# Altium schematic sheet DEVICE2.SchDoc(DEVICE2)
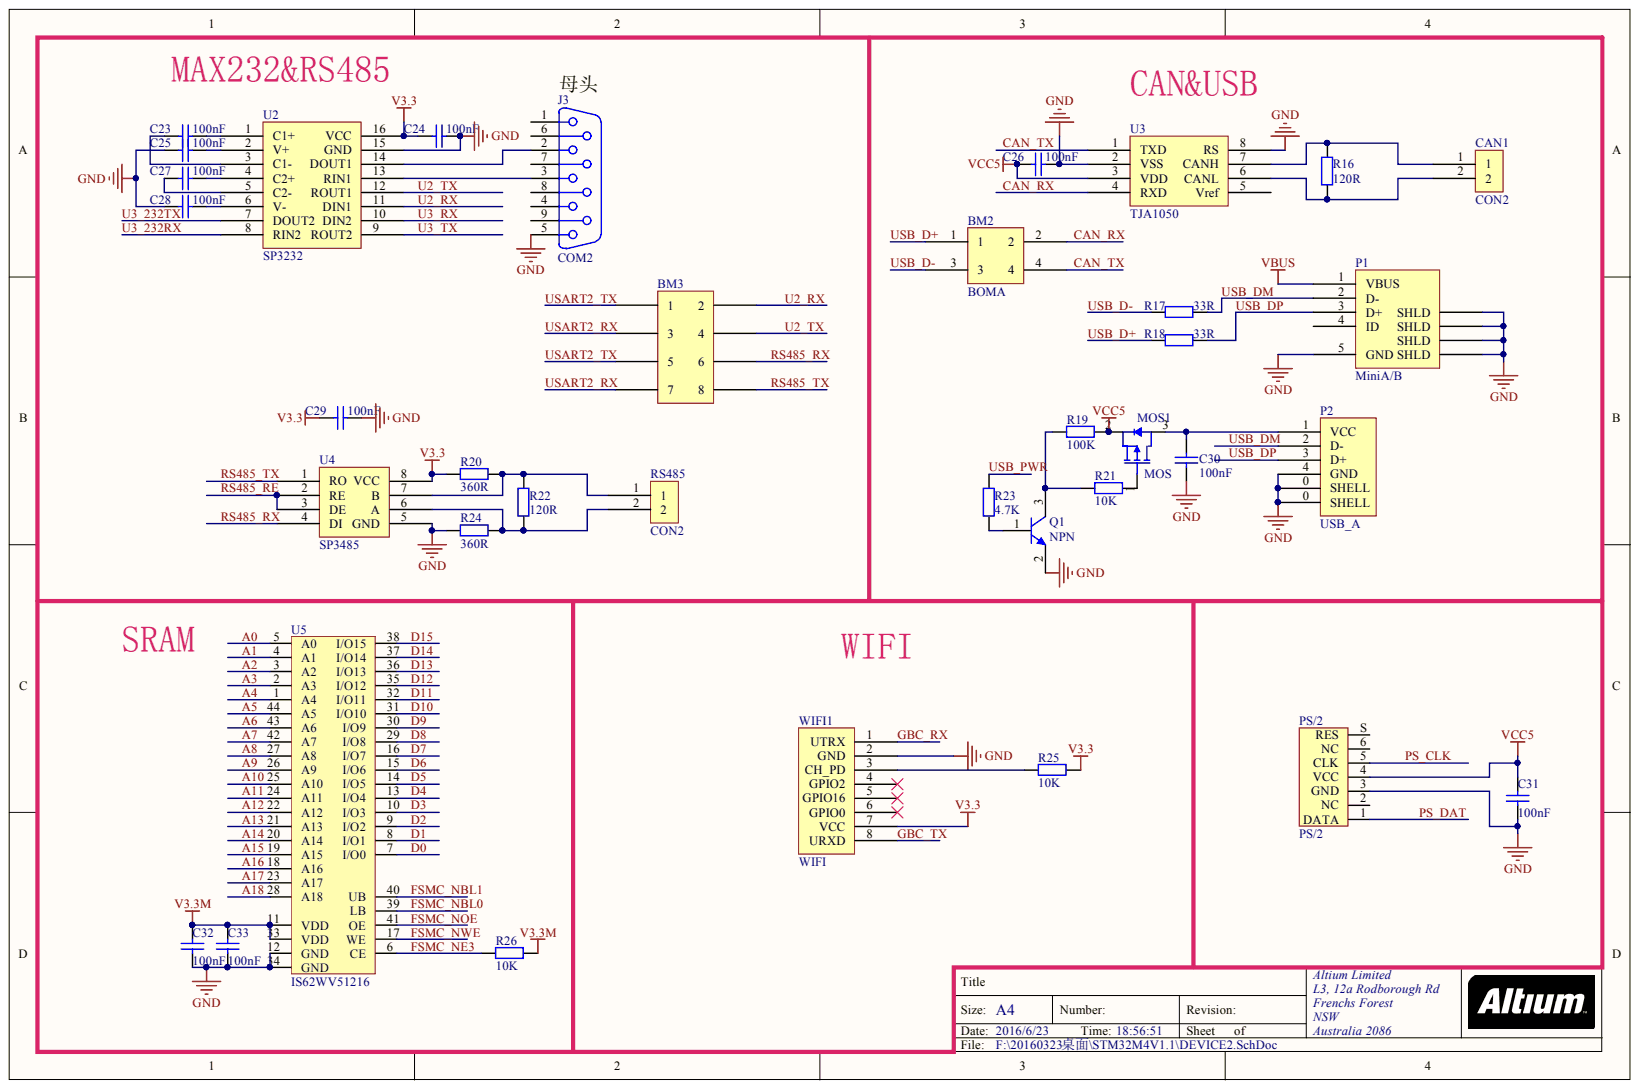
[diagram p0: DEVICE2.SchDoc]

## Parts
BM2  BOMA  [BM]
BM3  BOMA4  [BM4]  (value not drawn)
C23  value 100nF  [0603]  (hidden comment: Cap)
C24  value 100nF  [0603]  (hidden comment: Cap)
C25  value 100nF  [0603]  (hidden comment: Cap)
C26  value 100nF  [0603]  (hidden comment: Cap)
C27  value 100nF  [0603]  (hidden comment: Cap)
C28  value 100nF  [0603]  (hidden comment: Cap)
C29  value 100nF  [0603]  (hidden comment: Cap)
C30  value 100nF  [0603]  (hidden comment: Cap)
C31  value 100nF  [0603]  (hidden comment: Cap)
C32  value 100nF  [0603]  (hidden comment: Cap)
C33  value 100nF  [0603]  (hidden comment: Cap)
CAN1  CON2  [CON2]
J3  COM2  [DB9]
MOS1  MOS  [SOT-23]
P1  MiniA/B  [MINI_USB]
P2  USB_A  [USB_A]
PS/2  PS/2  [PS2-6PIN]
Q1  NPN
R16  value 120R  [0603]  (hidden comment: Res2)
R17  value 33R  [0603]  (hidden comment: Res2)
R18  value 33R  [0603]  (hidden comment: Res2)
R19  value 100K  [0603]  (hidden comment: Res2)
R20  value 360R  [0603]  (hidden comment: Res2)
R21  value 10K  [0603]  (hidden comment: Res2)
R22  value 120R  [0603]  (hidden comment: Res2)
R23  value 4.7K  [0603]  (hidden comment: Res2)
R24  value 360R  [0603]  (hidden comment: Res2)
R25  value 10K  [0603]  (hidden comment: Res2)
R26  value 10K  [0603]  (hidden comment: Res2)
RS485  CON2  [CON2]
U2  SP3232  [SOIC16]
U3  TJA1050  [SOIC8]
U4  SP3485  [SOIC8]
U5  IS62WV51216  [TSOP44]
WIFI1  WIFI  [WPZ_2x4]

## Nets
GND: C24.1 C25.2 C26.1 C28.2 C29.1 C30.2 C31.2 C32.2 C33.2 J3.5 P1.5(GND) P1.6(SHLD) P1.7(SHLD) P1.8(SHLD) P1.9(SHLD) P2.0(SHELL) P2.4(GND) PS/2.3(GND) Q1.2 R24.2 U2.15(GND) U3.2(VSS) U3.8(RS) U4.5(GND) U5.12(GND) U5.34(GND) WIFI1.2(GND)
V3.3: C24.2 C29.2 R20.2 R25.1 U2.16(VCC) U4.8(VCC) WIFI1.7(VCC)
V3.3M: C32.1 C33.1 R26.1 U5.11(VDD) U5.33(VDD)
VBUS: P1.1(VBUS)
VCC5: C26.2 C31.1 MOS1.2 PS/2.4(VCC) R19.1 U3.3(VDD)
A0: U5.5(A0)
A1: U5.4(A1)
A2: U5.3(A2)
A3: U5.2(A3)
A4: U5.1(A4)
A5: U5.44(A5)
A6: U5.43(A6)
A7: U5.42(A7)
A8: U5.27(A8)
A9: U5.26(A9)
A10: U5.25(A10)
A11: U5.24(A11)
A12: U5.22(A12)
A13: U5.21(A13)
A14: U5.20(A14)
A15: U5.19(A15)
A16: U5.18(A16)
A17: U5.23(A17)
A18: U5.28(A18)
CAN_RX: BM2.2 U3.4(RXD)
CAN_TX: BM2.4 U3.1(TXD)
D0: U5.7(I/O0)
D1: U5.8(I/O1)
D2: U5.9(I/O2)
D3: U5.10(I/O3)
D4: U5.13(I/O4)
D5: U5.14(I/O5)
D6: U5.15(I/O6)
D7: U5.16(I/O7)
D8: U5.29(I/O8)
D9: U5.30(I/O9)
D10: U5.31(I/O10)
D11: U5.32(I/O11)
D12: U5.35(I/O12)
D13: U5.36(I/O13)
D14: U5.37(I/O14)
D15: U5.38(I/O15)
FSMC_NBL0: U5.39(LB)
FSMC_NBL1: U5.40(UB)
FSMC_NE3: R26.2 U5.6(CE)
FSMC_NOE: U5.41(OE)
FSMC_NWE: U5.17(WE)
GBC_RX: WIFI1.1(UTRX)
GBC_TX: WIFI1.8(URXD)
PS_CLK: PS/2.5(CLK)
PS_DAT: PS/2.1(DATA)
RS485_RE: U4.2(RE) U4.3(DE)
RS485_RX: BM3.6 U4.4(DI)
RS485_TX: BM3.8 U4.1(RO)
U2_RX: BM3.2 U2.11(DIN1)
U2_TX: BM3.4 U2.12(ROUT1)
U3_232RX: U2.8(RIN2)
U3_232TX: U2.7(DOUT2)
U3_RX: U2.10(DIN2)
U3_TX: U2.9(ROUT2)
USART2_RX: BM3.3 BM3.7
USART2_TX: BM3.1 BM3.5
USB_D+: BM2.1 R18.2
USB_D-: BM2.3 R17.2
USB_DM: P1.2(D-) P2.2(D-) R17.1
USB_DP: P1.3(D+) P2.3(D+) R18.1
USB_PWR: R23.1
NetC23_1: C23.1 U2.1(C1+)
NetC23_2: C23.2 U2.3(C1-)
NetC25_1: C25.1 U2.2(V+)
NetC27_1: C27.1 U2.4(C2+)
NetC27_2: C27.2 U2.5(C2-)
NetC28_1: C28.1 U2.6(V-)
NetC30_1: C30.1 MOS1.3 P2.1(VCC)
NetCAN1_1: CAN1.1 R16.2 U3.7(CANH)
NetCAN1_2: CAN1.2 R16.1 U3.6(CANL)
NetJ3_2: J3.2 U2.14(DOUT1)
NetJ3_3: J3.3 U2.13(RIN1)
NetMOS1_1: MOS1.1 R21.1
NetQ1_1: Q1.1 R23.2
NetQ1_3: Q1.3 R19.2 R21.2
NetR20_1: R20.1 R22.2 RS485.1 U4.7(B)
NetR22_1: R22.1 R24.1 RS485.2 U4.6(A)
NetR25_2: R25.2 WIFI1.3(CH_PD)

Not connected: J3 1 4 6 7 8 9; P1 4(ID); PS/2 2(NC) 6(NC) S(RES); U3 5(Vref); WIFI1 4(GPIO2) 5(GPIO16) 6(GPIO0)

## Library data of the parts
C23-C33 = Cap: Capacitor; Component Kind: Standard; LatestRevisionDate: 17-Jul-2002; LatestRevisionNote: Re-released for DXP Platform.; Library Name: Miscellaneous Devices.IntLib; PackageDescription: Radial Cap, Thru-Hole; 2 Leads; 0.3 in Pin Spacing; PackageReference: RAD-0.3; Published: 8-Jun-2000; Publisher: Altium Limited
  C23-C30 C32 C33: Signal Integrity: Cap; Simulation: CAP
J3 = DB-9: Component Kind: Standard; Library Name: MyLibrary.IntLib
PS/2 = P/S2: Component Kind: Standard; Library Name: 战舰STM32开发板底板封装库.IntLib
R16-R26 = Res2: Resistor; Component Kind: Standard; LatestRevisionDate: 17-Jul-2002; LatestRevisionNote: Re-released for DXP Platform.; Library Name: Miscellaneous Devices.IntLib; PackageDescription: Axial Device, Thru-Hole; 2 Leads; 0.4 in Pin Spacing; PackageReference: AXIAL-0.4; Published: 8-Jun-2000; Signal Integrity: Res; Simulation: RESISTOR; Publisher: Altium Limited
U2 = MAX3232: Component Kind: Standard; Library Name: MyLibrary.IntLib
U3 = SN65VD230: CAN收发器; Component Kind: Standard; Library Name: MyLibrary.IntLib
U4 = MAX485: Component Kind: Standard; Library Name: MyLibrary.IntLib
BM2 = BOMA: Component Kind: Standard; Library Name: WARSHIP.SCHLIB
BM3 = BOMA4: Component Kind: Standard; Library Name: WARSHIP.SCHLIB
CAN1 RS485 = CON2: Component Kind: Standard
  RS485: Library Name: M4.SCHLIB
MOS1 = MOS: Component Kind: Standard; Description: MOS; Library Name: MyLibrary.SchLib
P1 = MiniA/B: Component Kind: Standard; Library Name: MyLibrary.IntLib
P2 = USB_A: Component Kind: Standard; Description: USB_A; Library Name: MyLibrary.IntLib
Q1 = NPN: Component Kind: Standard; Library Name: MyLibrary.SchLib
U5 = IS62WV51216: Component Kind: Standard; Library Name: MyLibrary.IntLib
WIFI1 = WIFI: Component Kind: Standard; Library Name: WARSHIP.SCHLIB
